# Altium schematic sheet SK-HC32L51-64LQFP-MCU.SchDoc
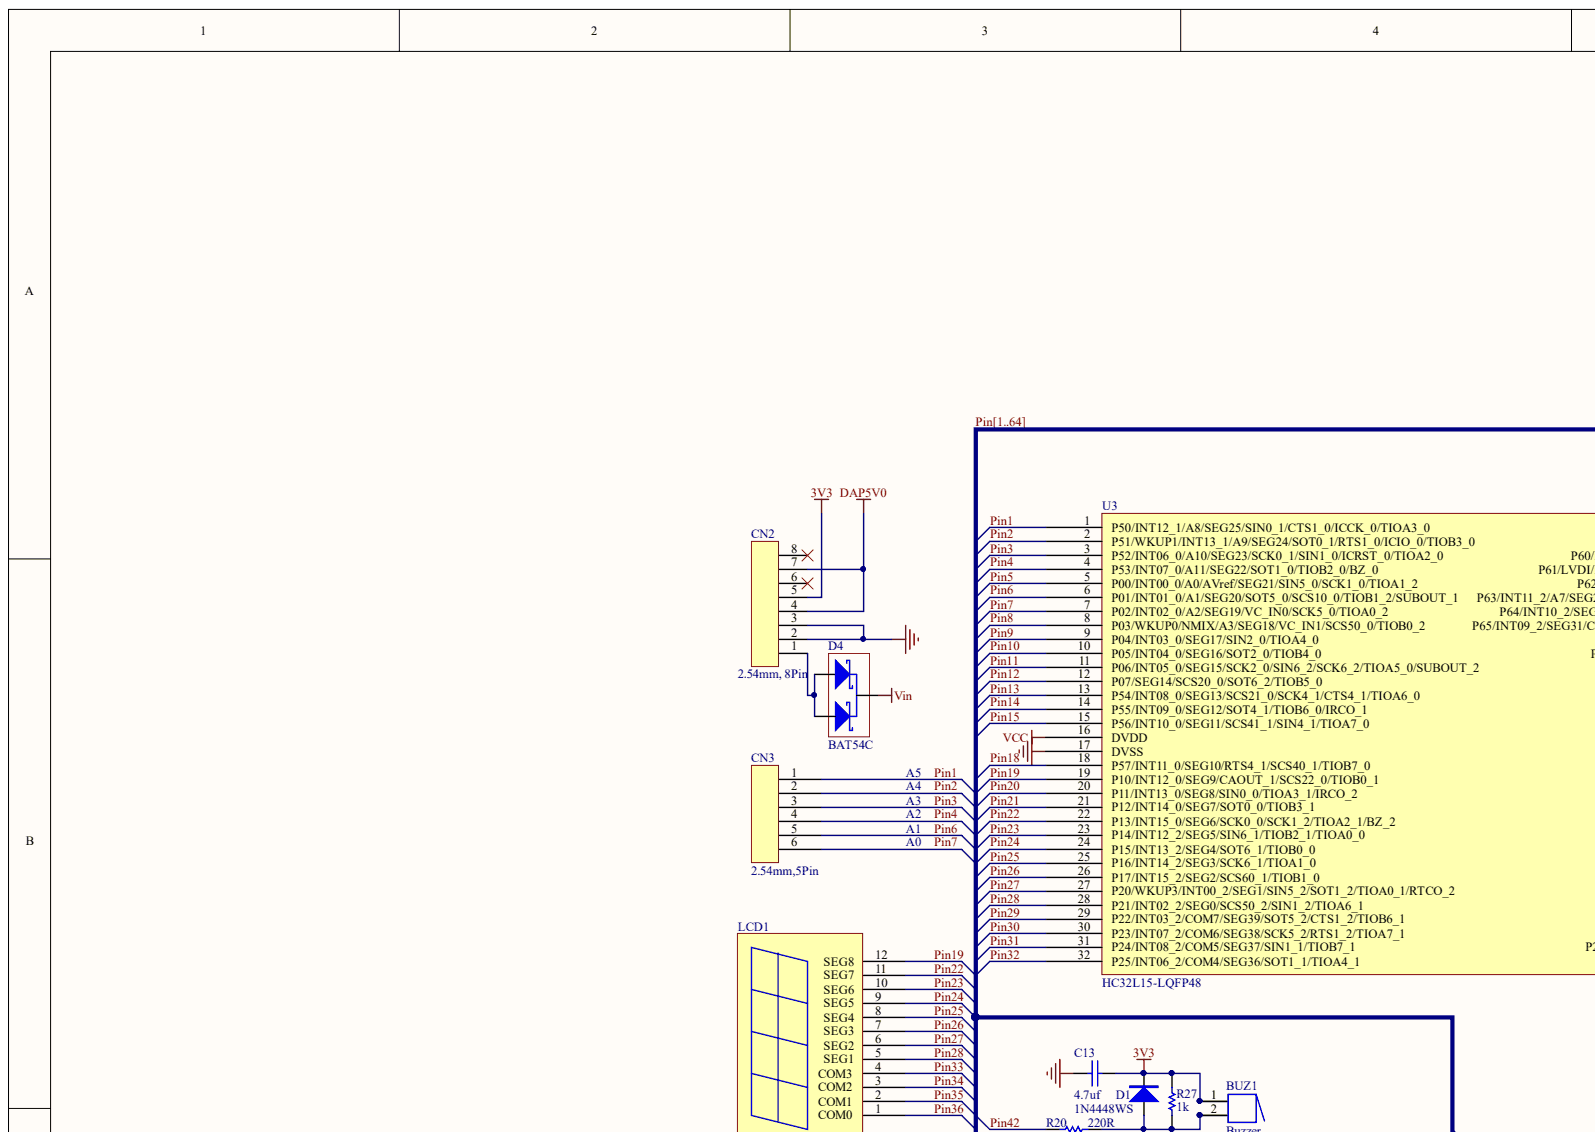
[diagram p0: SK-HC32L51-64LQFP-MCU.SchDoc, top left]
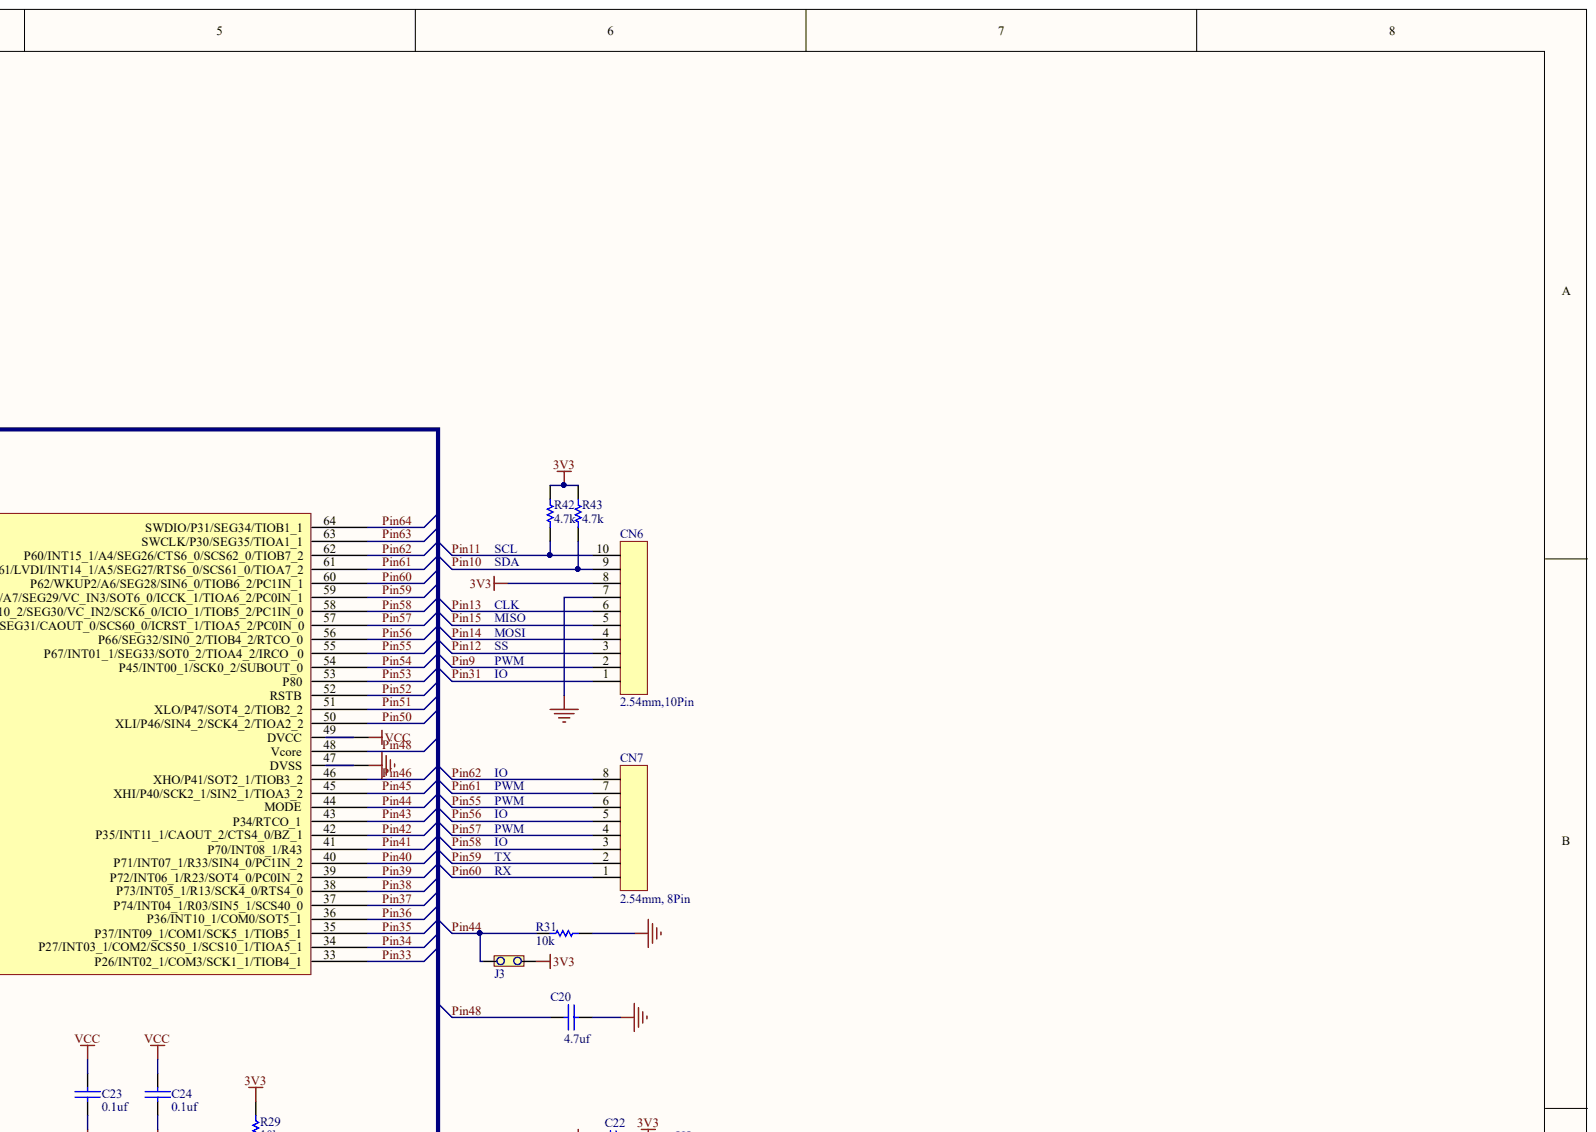
[diagram p1: SK-HC32L51-64LQFP-MCU.SchDoc, top right]
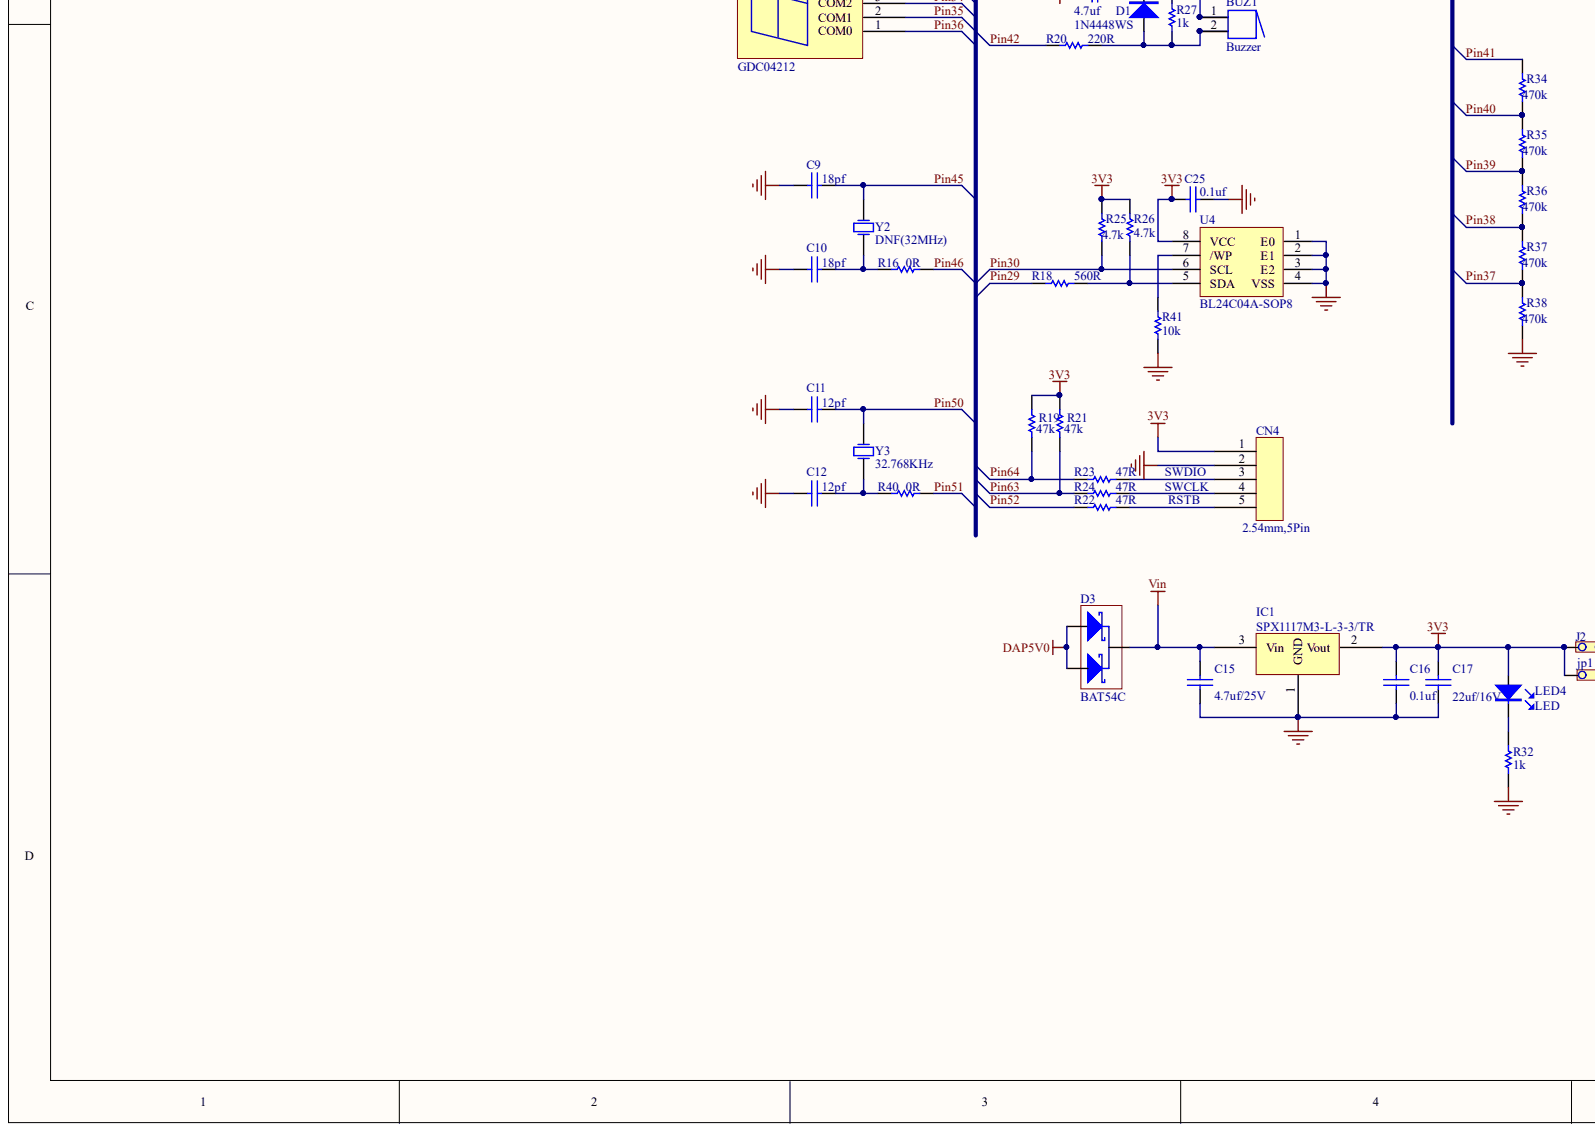
[diagram p2: SK-HC32L51-64LQFP-MCU.SchDoc, bottom left]
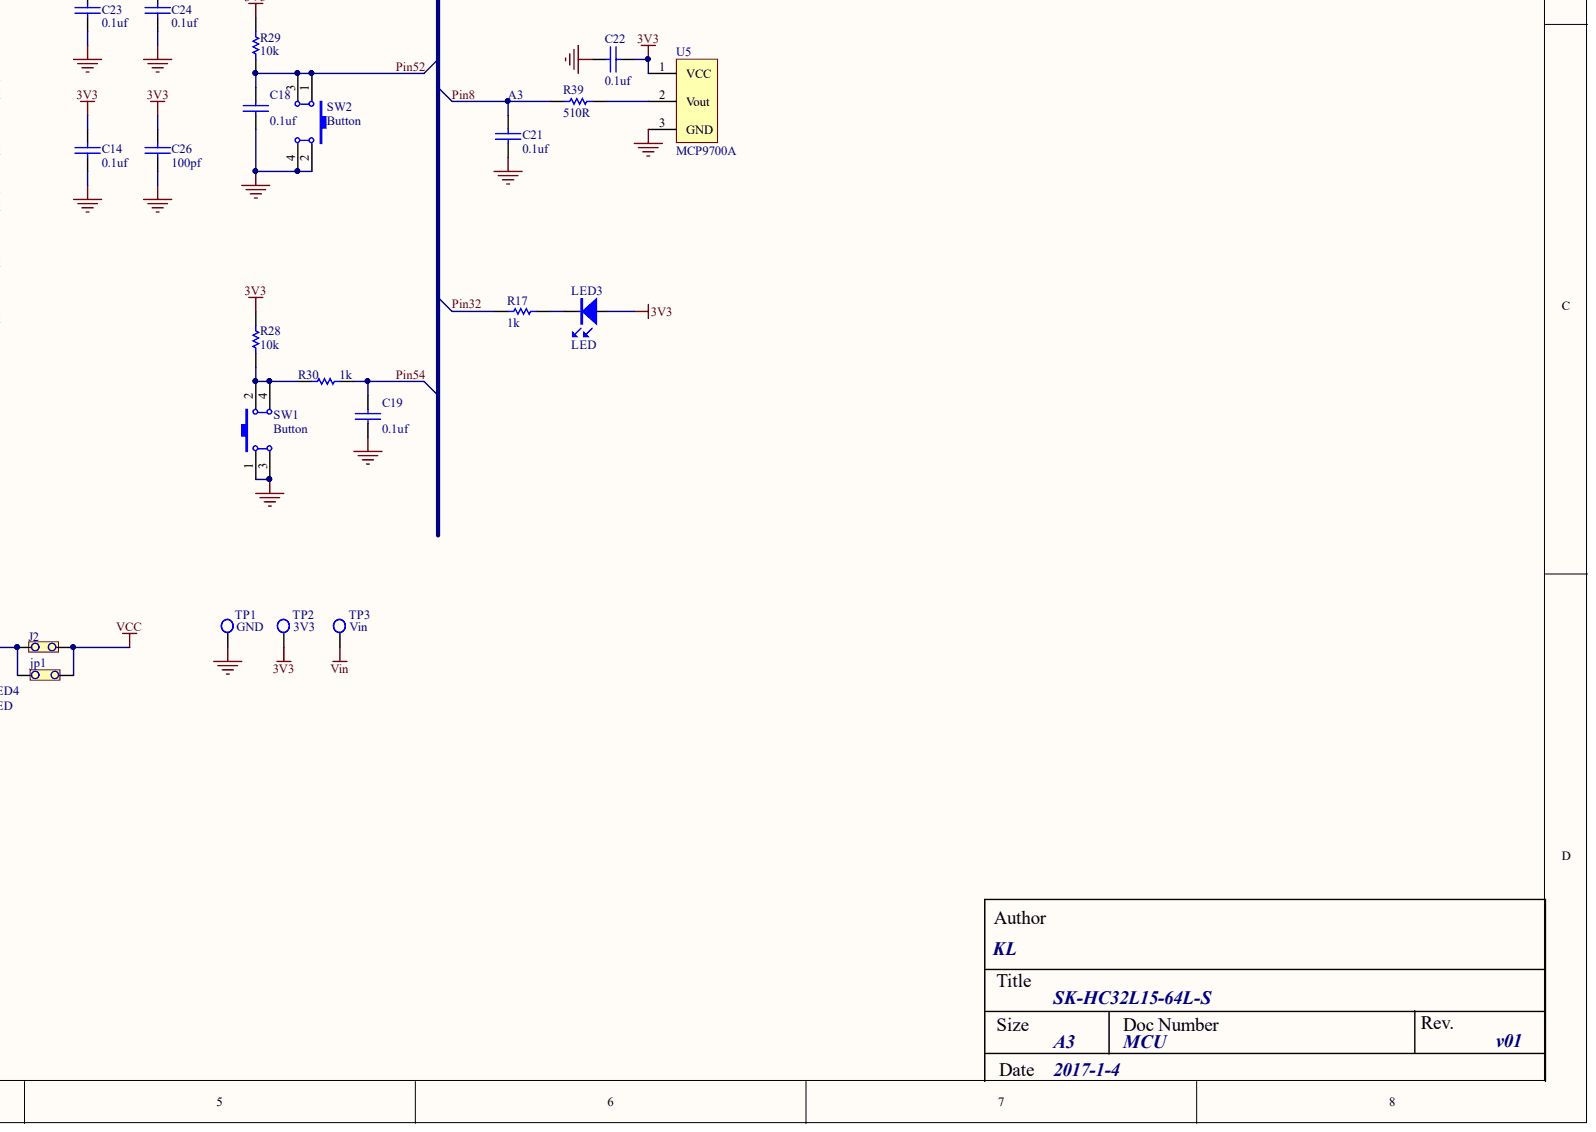
[diagram p3: SK-HC32L51-64LQFP-MCU.SchDoc, bottom right]

## Parts
BUZ1  Buzzer  [Buzzer]
C9  18pf  [c0603]
C10  18pf  [c0603]
C11  12pf  [c0603]
C12  12pf  [c0603]
C13  4.7uf  [c0805]
C14  0.1uf  [c0603]
C15  4.7uf/25V  [c0805]
C16  0.1uf  [c0603]
C17  22uf/16V  [c1206]
C18  0.1uf  [c0603]
C19  0.1uf  [c0603]
C20  4.7uf  [c0805]
C21  0.1uf  [c0603]
C22  0.1uf  [c0603]
C23  0.1uf  [c0603]
C24  0.1uf  [c0603]
C25  0.1uf  [c0603]
C26  100pf  [c0603]
CN2  2.54mm, 8Pin  [PIN-8-254-S]
CN3  2.54mm,5Pin  [PIN-6-254-S]
CN4  2.54mm,5Pin  [PIN-5-254-S]
CN6  2.54mm,10Pin  [PIN-10-254-S]
CN7  2.54mm, 8Pin  [PIN-8-254-S]
D1  1N4448WS  [SOD-323]
D3  BAT54C  [SOT-23]
D4  BAT54C  [SOT-23]
IC1  SPX1117M3-L-3-3/TR  [SOT-223]
J2  2.54mm,2pins  [JMP-2.54]  (value not drawn)
J3  2.54mm,2pins  [JMP-2.54]  (value not drawn)
jp1  jumper wire  [jpo-0603]  (value not drawn)
LCD1  GDC04212  [GDC04212]
LED3  LED  [LED0603]
LED4  LED  [LED0603]
R16  0R  [R0603]
R17  1k  [R0603]
R18  560R  [R0603]
R19  47k  [R0603]
R20  220R  [R0603]
R21  47k  [R0603]
R22  47R  [R0603]
R23  47R  [R0603]
R24  47R  [R0603]
R25  4.7k  [R0603]
R26  4.7k  [R0603]
R27  1k  [R0603]
R28  10k  [R0603]
R29  10k  [R0603]
R30  1k  [R0603]
R31  10k  [R0603]
R32  1k  [R0603]
R34  470k  [R0603]
R35  470k  [R0603]
R36  470k  [R0603]
R37  470k  [R0603]
R38  470k  [R0603]
R39  510R  [R0603]
R40  0R  [R0603]
R41  10k  [R0603]
R42  4.7k  [R0603]
R43  4.7k  [R0603]
SW1  Button  [PUSHBUTTON]
SW2  Button  [PUSHBUTTON]
TP1  GND  [TP]
TP2  3V3  [TP]
TP3  Vin  [TP]
U3  HC32L15-LQFP48  [LQFP-64-090-040]
U4  BL24C04A-SOP8  [SOIC-8]
U5  MCP9700A  [SOT-23]
Y2  DNF(32MHz)  [49S]
Y3  32.768KHz  [32.768K]

## Nets
3V3: BUZ1.1 BUZ1.1A C13.2 C14.1 C16.2 C17.2 C22.1 C25.2 C26.1 CN2.5 CN4.1 CN6.8 D1.1 IC1.2 J2.1 J3.2 jp1.1 LED3.1 LED4.1 R19.2 R21.2 R25.2 R26.2 R27.2 R28.2 R29.2 R42.2 R43.2 TP2.1 U4.8(VCC) U5.1
DAP5V0: CN2.4 CN2.7 D3.1 D3.2
GND: C9.2 C10.2 C11.2 C12.2 C13.1 C14.2 C15.1 C16.1 C17.1 C18.1 C19.1 C20.2 C21.2 C22.2 C23.2 C24.2 C25.1 C26.2 CN2.2 CN2.3 CN4.2 CN6.7 IC1.1 R31.2 R32.2 R38.1 R41.1 SW1.1 SW1.3 SW2.2 SW2.4 TP1.1 U3.17(DVSS) U3.47(DVSS) U4.1(E0) U4.2(E1) U4.3(E2) U4.4(VSS) U5.3
VCC: C23.1 C24.1 J2.2 jp1.2 U3.16(DVDD) U3.49(DVCC)
VIN: C15.2 D3.3 D4.3 IC1.3 TP3.1
PIN1: CN3.1 U3.1(P50/INT12_1/A8/SEG25/SIN0_1/CTS1_0/ICCK_0/TIOA3_0)  [also labelled PIN]
PIN2: CN3.2 U3.2(P51/WKUP1/INT13_1/A9/SEG24/SOT0_1/RTS1_0/ICIO_0/TIOB3_0)  [also labelled PIN]
PIN3: CN3.3 U3.3(P52/INT06_0/A10/SEG23/SCK0_1/SIN1_0/ICRST_0/TIOA2_0)  [also labelled PIN]
PIN4: CN3.4 U3.4(P53/INT07_0/A11/SEG22/SOT1_0/TIOB2_0/BZ_0)  [also labelled PIN]
PIN5: U3.5(P00/INT00_0/A0/AVref/SEG21/SIN5_0/SCK1_0/TIOA1_2)  [also labelled PIN]
PIN6: CN3.5 U3.6(P01/INT01_0/A1/SEG20/SOT5_0/SCS10_0/TIOB1_2/SUBOUT_1)  [also labelled PIN]
PIN7: CN3.6 U3.7(P02/INT02_0/A2/SEG19/VC_IN0/SCK5_0/TIOA0_2)  [also labelled PIN]
PIN8: C21.1 R39.2 U3.8(P03/WKUP0/NMIX/A3/SEG18/VC_IN1/SCS50_0/TIOB0_2)  [also labelled PIN]
PIN9: CN6.2 U3.9(P04/INT03_0/SEG17/SIN2_0/TIOA4_0)  [also labelled PIN]
PIN10: CN6.9 R43.1 U3.10(P05/INT04_0/SEG16/SOT2_0/TIOB4_0)  [also labelled PIN]
PIN11: CN6.10 R42.1 U3.11(P06/INT05_0/SEG15/SCK2_0/SIN6_2/SCK6_2/TIOA5_0/SUBOUT_2)  [also labelled PIN]
PIN12: CN6.3 U3.12(P07/SEG14/SCS20_0/SOT6_2/TIOB5_0)  [also labelled PIN]
PIN13: CN6.6 U3.13(P54/INT08_0/SEG13/SCS21_0/SCK4_1/CTS4_1/TIOA6_0)  [also labelled PIN]
PIN14: CN6.4 U3.14(P55/INT09_0/SEG12/SOT4_1/TIOB6_0/IRCO_1)  [also labelled PIN]
PIN15: CN6.5 U3.15(P56/INT10_0/SEG11/SCS41_1/SIN4_1/TIOA7_0)  [also labelled PIN]
PIN18: U3.18(P57/INT11_0/SEG10/RTS4_1/SCS40_1/TIOB7_0)  [also labelled PIN]
PIN19: LCD1.12(SEG8) U3.19(P10/INT12_0/SEG9/CAOUT_1/SCS22_0/TIOB0_1)  [also labelled PIN]
PIN20: U3.20(P11/INT13_0/SEG8/SIN0_0/TIOA3_1/IRCO_2)  [also labelled PIN]
PIN21: U3.21(P12/INT14_0/SEG7/SOT0_0/TIOB3_1)  [also labelled PIN]
PIN22: LCD1.11(SEG7) U3.22(P13/INT15_0/SEG6/SCK0_0/SCK1_2/TIOA2_1/BZ_2)  [also labelled PIN]
PIN23: LCD1.10(SEG6) U3.23(P14/INT12_2/SEG5/SIN6_1/TIOB2_1/TIOA0_0)  [also labelled PIN]
PIN24: LCD1.9(SEG5) U3.24(P15/INT13_2/SEG4/SOT6_1/TIOB0_0)  [also labelled PIN]
PIN25: LCD1.8(SEG4) U3.25(P16/INT14_2/SEG3/SCK6_1/TIOA1_0)  [also labelled PIN]
PIN26: LCD1.7(SEG3) U3.26(P17/INT15_2/SEG2/SCS60_1/TIOB1_0)  [also labelled PIN]
PIN27: LCD1.6(SEG2) U3.27(P20/WKUP3/INT00_2/SEG1/SIN5_2/SOT1_2/TIOA0_1/RTCO_2)  [also labelled PIN]
PIN28: LCD1.5(SEG1) U3.28(P21/INT02_2/SEG0/SCS50_2/SIN1_2/TIOA6_1)  [also labelled PIN]
PIN29: R18.1 U3.29(P22/INT03_2/COM7/SEG39/SOT5_2/CTS1_2/TIOB6_1)  [also labelled PIN]
PIN30: R25.1 U3.30(P23/INT07_2/COM6/SEG38/SCK5_2/RTS1_2/TIOA7_1) U4.6(SCL)  [also labelled PIN]
PIN31: CN6.1 U3.31(P24/INT08_2/COM5/SEG37/SIN1_1/TIOB7_1)  [also labelled PIN]
PIN32: R17.2 U3.32(P25/INT06_2/COM4/SEG36/SOT1_1/TIOA4_1)  [also labelled PIN]
PIN33: LCD1.4(COM3) U3.33(P26/INT02_1/COM3/SCK1_1/TIOB4_1)  [also labelled PIN]
PIN34: LCD1.3(COM2) U3.34(P27/INT03_1/COM2/SCS50_1/SCS10_1/TIOA5_1)  [also labelled PIN]
PIN35: LCD1.2(COM1) U3.35(P37/INT09_1/COM1/SCK5_1/TIOB5_1)  [also labelled PIN]
PIN36: LCD1.1(COM0) U3.36(P36/INT10_1/COM0/SOT5_1)  [also labelled PIN]
PIN37: R37.1 R38.2 U3.37(P74/INT04_1/R03/SIN5_1/SCS40_0)  [also labelled PIN]
PIN38: R36.1 R37.2 U3.38(P73/INT05_1/R13/SCK4_0/RTS4_0)  [also labelled PIN]
PIN39: R35.1 R36.2 U3.39(P72/INT06_1/R23/SOT4_0/PC0IN_2)  [also labelled PIN]
PIN40: R34.1 R35.2 U3.40(P71/INT07_1/R33/SIN4_0/PC1IN_2)  [also labelled PIN]
PIN41: R34.2 U3.41(P70/INT08_1/R43)  [also labelled PIN]
PIN42: R20.1 U3.42(P35/INT11_1/CAOUT_2/CTS4_0/BZ_1)  [also labelled PIN]
PIN43: U3.43(P34/RTCO_1)  [also labelled PIN]
PIN44: J3.1 R31.1 U3.44(MODE)  [also labelled PIN]
PIN45: C9.1 U3.45(XHI/P40/SCK2_1/SIN2_1/TIOA3_2) Y2.2  [also labelled PIN]
PIN46: R16.2 U3.46(XHO/P41/SOT2_1/TIOB3_2)  [also labelled PIN]
PIN48: C20.1 U3.48(Vcore)  [also labelled PIN]
PIN50: C11.1 U3.50(XLI/P46/SIN4_2/SCK4_2/TIOA2_2) Y3.2  [also labelled PIN]
PIN51: R40.2 U3.51(XLO/P47/SOT4_2/TIOB2_2)  [also labelled PIN]
PIN52: C18.2 R22.1 R29.1 SW2.1 SW2.3 U3.52(RSTB)  [also labelled PIN]
PIN53: U3.53(P80)  [also labelled PIN]
PIN54: C19.2 R30.2 U3.54(P45/INT00_1/SCK0_2/SUBOUT_0)  [also labelled PIN]
PIN55: CN7.6 U3.55(P67/INT01_1/SEG33/SOT0_2/TIOA4_2/IRCO__0)  [also labelled PIN]
PIN56: CN7.5 U3.56(P66/SEG32/SIN0_2/TIOB4_2/RTCO_0)  [also labelled PIN]
PIN57: CN7.4 U3.57(P65/INT09_2/SEG31/CAOUT_0/SCS60_0/ICRST_1/TIOA5_2/PC0IN_0)  [also labelled PIN]
PIN58: CN7.3 U3.58(P64/INT10_2/SEG30/VC_IN2/SCK6_0/ICIO_1/TIOB5_2/PC1IN_0)  [also labelled PIN]
PIN59: CN7.2 U3.59(P63/INT11_2/A7/SEG29/VC_IN3/SOT6_0/ICCK_1/TIOA6_2/PC0IN_1)  [also labelled PIN]
PIN60: CN7.1 U3.60(P62/WKUP2/A6/SEG28/SIN6_0/TIOB6_2/PC1IN_1)  [also labelled PIN]
PIN61: CN7.7 U3.61(P61/LVDI/INT14_1/A5/SEG27/RTS6_0/SCS61_0/TIOA7_2)  [also labelled PIN]
PIN62: CN7.8 U3.62(P60/INT15_1/A4/SEG26/CTS6_0/SCS62_0/TIOB7_2)  [also labelled PIN]
PIN63: R21.1 R24.1 U3.63(SWCLK/P30/SEG35/TIOA1_1)  [also labelled PIN]
PIN64: R19.1 R23.1 U3.64(SWDIO/P31/SEG34/TIOB1_1)  [also labelled PIN]
NetBUZ1_2: BUZ1.2 BUZ1.2A D1.2 R20.2 R27.1
NetC10_1: C10.1 R16.1 Y2.1
NetC12_1: C12.1 R40.1 Y3.1
NetCN2_1: CN2.1 D4.1 D4.2
NetCN4_3: CN4.3 R23.2
NetCN4_4: CN4.4 R24.2
NetCN4_5: CN4.5 R22.2
NetLED3_2: LED3.2 R17.1
NetLED4_2: LED4.2 R32.1
NetR18_2: R18.2 R26.1 U4.5(SDA)
NetR28_1: R28.1 R30.1 SW1.2 SW1.4
NetR39_1: R39.1 U5.2
NetR41_2: R41.2 U4.7(/WP)
N00004:
N00005:
PIN16:  [also labelled PIN]
PIN17:  [also labelled PIN]
PIN47:  [also labelled PIN]
PIN49:  [also labelled PIN]

Not connected: CN2 6 8

## Library data of the parts
C9-C26 = CAP-CC(SMD): Ceramic Capacitor,0603; Component Kind: Standard; Library Name: MyLib_Altium.SCHLIB
CN2 CN7 = PIN-1-8-254: Arduino header; Component Kind: Standard; Library Name: MyLib_Altium.SCHLIB
CN3 = PIN-1-6-254: Value: 2.54mm,4pin; Component Kind: Standard; Library Name: MyLib_Altium.SCHLIB
CN4 = PIN-1-5-254: Value: 2.54mm,4pin; Component Kind: Standard; Library Name: MyLib_Altium.SCHLIB
CN6 = PIN-1-10-254: Arduino header; Component Kind: Standard; Library Name: MyLib_Altium.SCHLIB
D1 = DIODE: Text Field1: 4007; Component Kind: Standard; Library Name: MyLib_Altium.SCHLIB
D3 D4 = BAT54C: Dual schotty diode, ON semi; Component Kind: Standard; Library Name: MyLib_Altium.SCHLIB
IC1 = SPX1117M3-L-3-3/TR: LDO, Vout = 3V3; Component Kind: Standard; Library Name: MyLib_Altium.SCHLIB
J2 J3 = Jumper-2: 2.54mm, 2pin jumper; Component Kind: Standard; LatestRevisionDate: 17-Jul-2002; LatestRevisionNote: Re-released for DXP Platform.; Library Name: MyLib_Altium.SCHLIB; PackageReference: RAD-0.2; Published: 24-Mar-1999; Publisher: Altium Limited
jp1 = Jpo: default open jumper wire,0603; Component Kind: Standard; Library Name: MyLib_Altium.SCHLIB
LCD1 = GDC04212: LCD; Component Kind: Standard; Library Name: MyLib_Altium.SCHLIB
LED3 LED4 = LED: 0603,SMT; Component Kind: Standard; Library Name: MyLib_Altium.SCHLIB
R16 R18-R28 R30 R34-R38 R40 R41 = RES: Resister,0603,5%; Value: 100R; Component Kind: Standard; Library Name: MyLib_Altium.SCHLIB
R17 R29 R31 R32 R39 R42 R43 = RES: Resister,0603,5%; Component Kind: Standard; Library Name: MyLib_Altium.SCHLIB
SW1 SW2 = Button-SMD-6*6: SMD button,6*6; Component Kind: Standard; Library Name: MyLib_Altium.SCHLIB
TP1 = Testpoint: Component Kind: Standard; Library Name: MyLib_Altium.SCHLIB; Value: GND
TP2 TP3 = Testpoint: Value: GND; Component Kind: Standard; Library Name: MyLib_Altium.SCHLIB
U3 = HD321LC: 0.4mm,LQFP64,HDSC; Component Kind: Standard; Library Name: MyLib_Altium.SCHLIB
U4 = M24256: 32kB EEPROM, ST; Component Kind: Standard; Library Name: MyLib_Altium.SCHLIB
U5 = MCP9700A: Temperature Sensor,SOT-23; Component Kind: Standard; Library Name: MyLib_Altium.SCHLIB
Y2 Y3 = Crystal: 4.0000MHz; Component Kind: Standard; Library Name: MyLib_Altium.SCHLIB
BUZ1 = Buzzer: Component Kind: Standard; Library Name: MyLib_Altium.SCHLIB; Value: Buzzer
